# Altium schematic sheet Misc.SCHDOC(Misc)
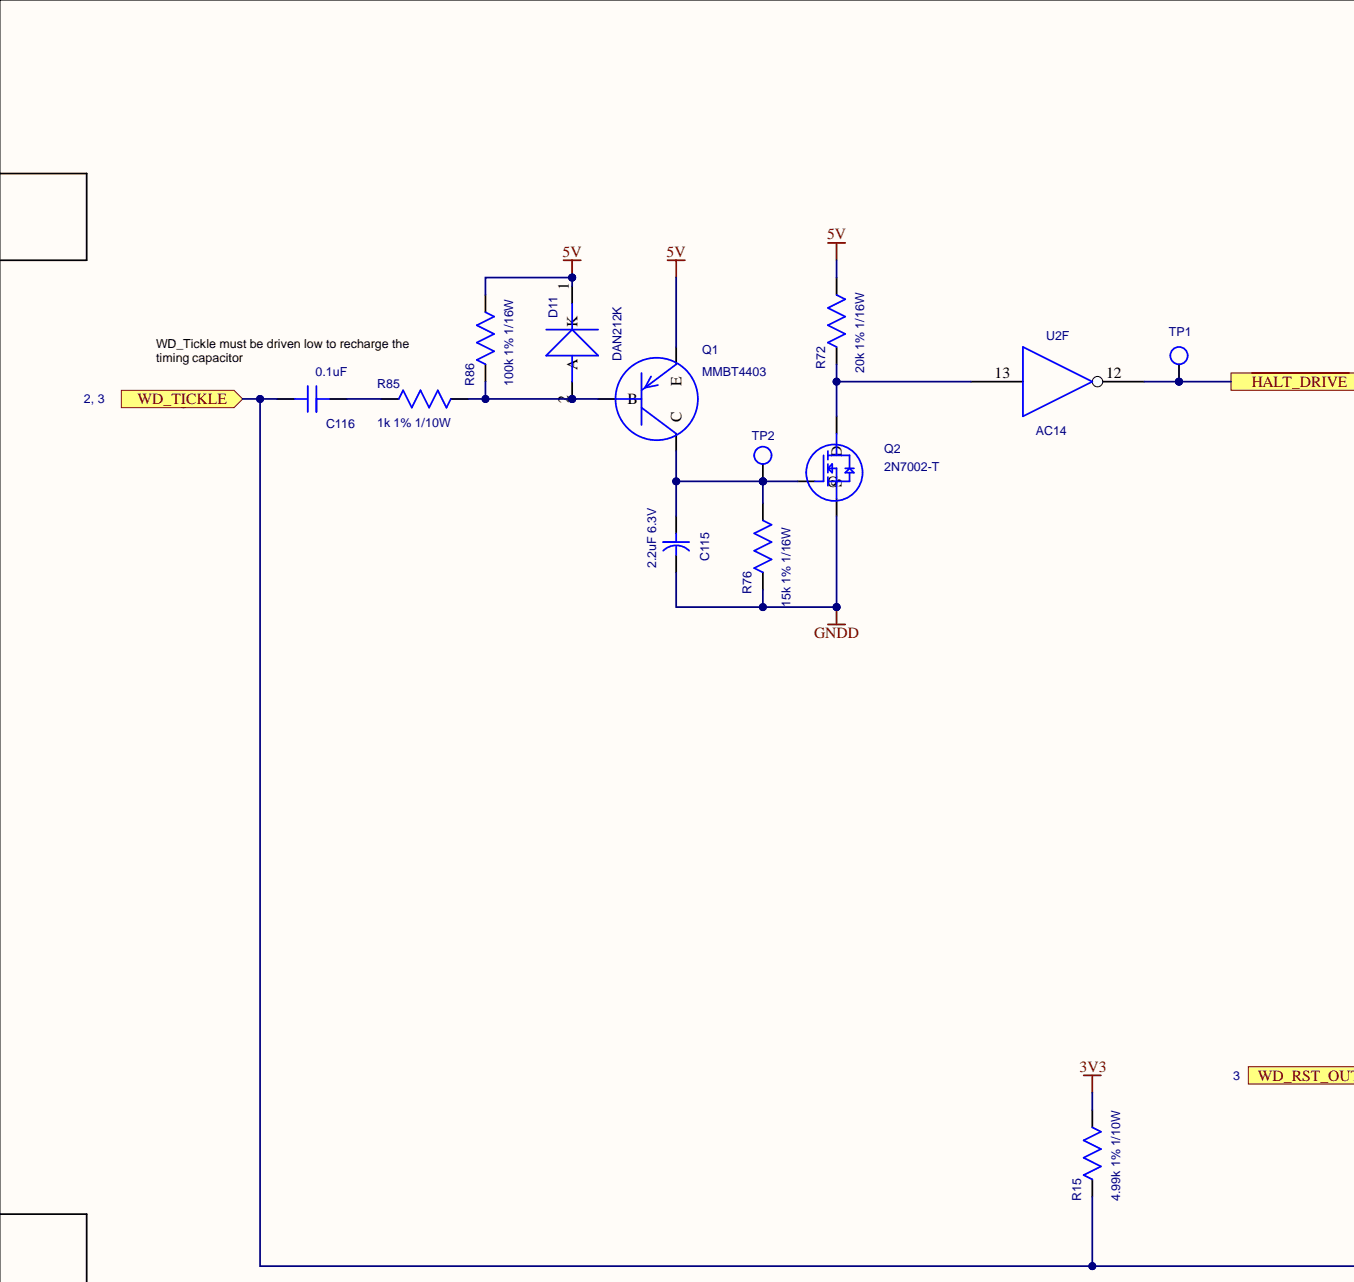
[diagram p0: Misc.SCHDOC, top left]
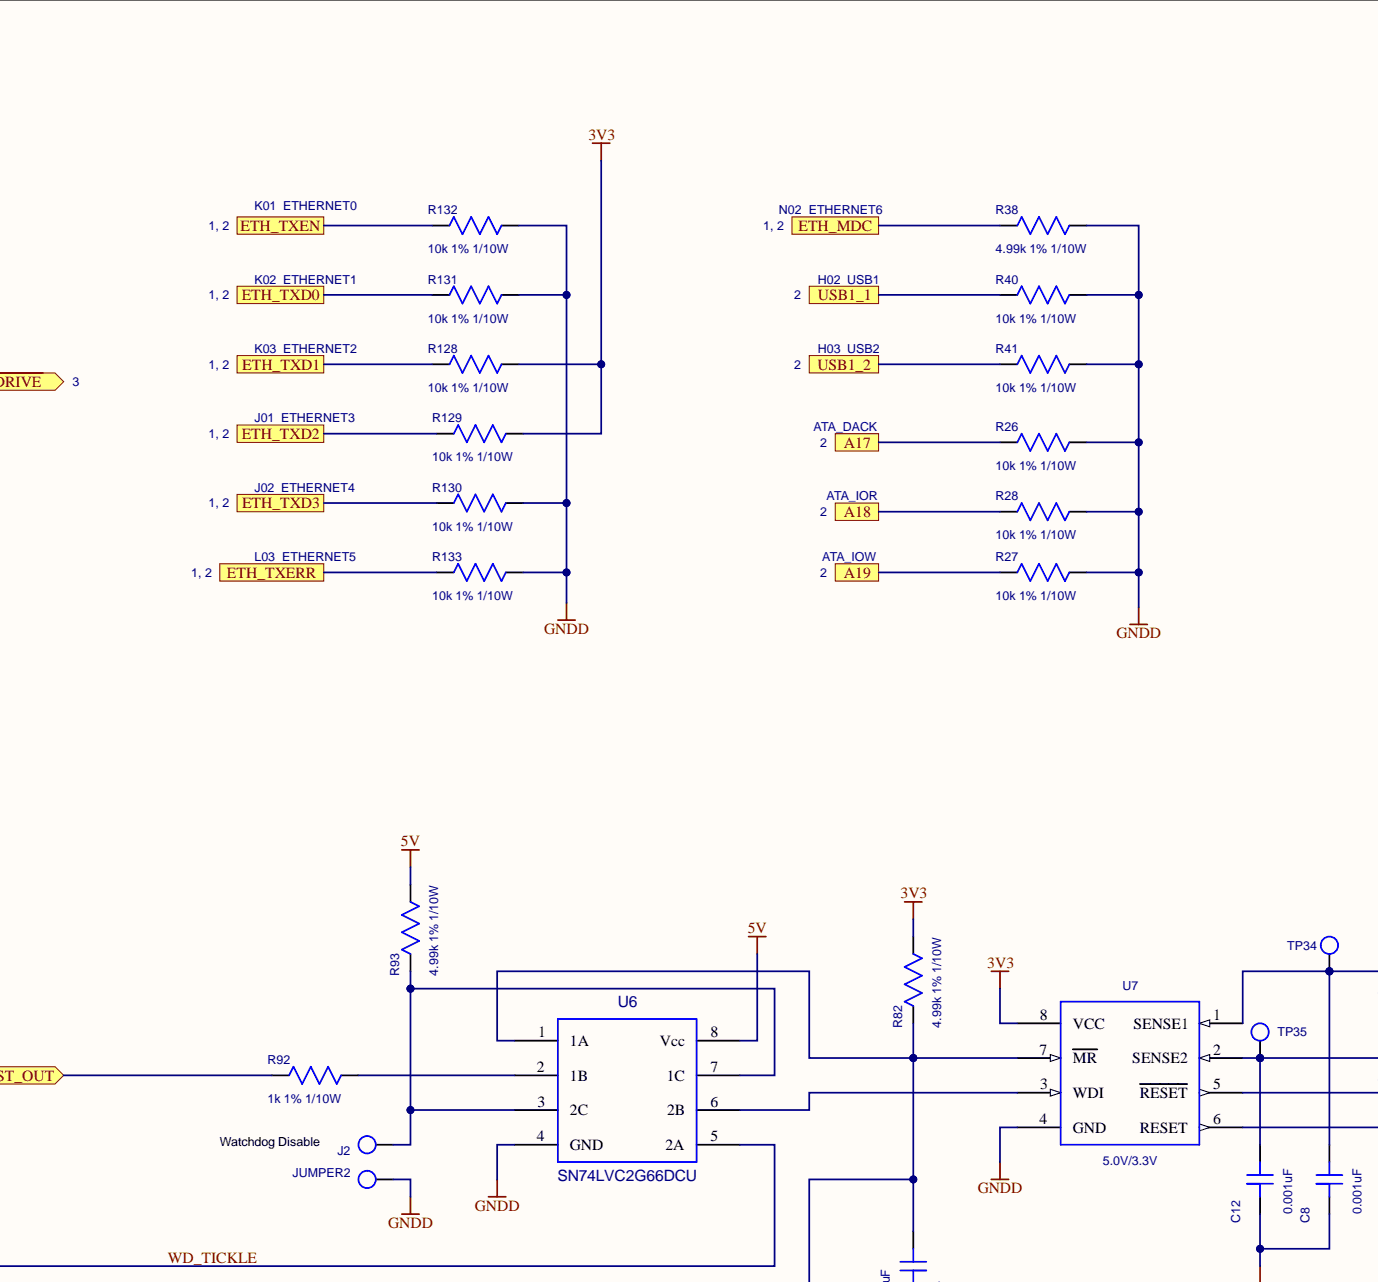
[diagram p1: Misc.SCHDOC, top centre]
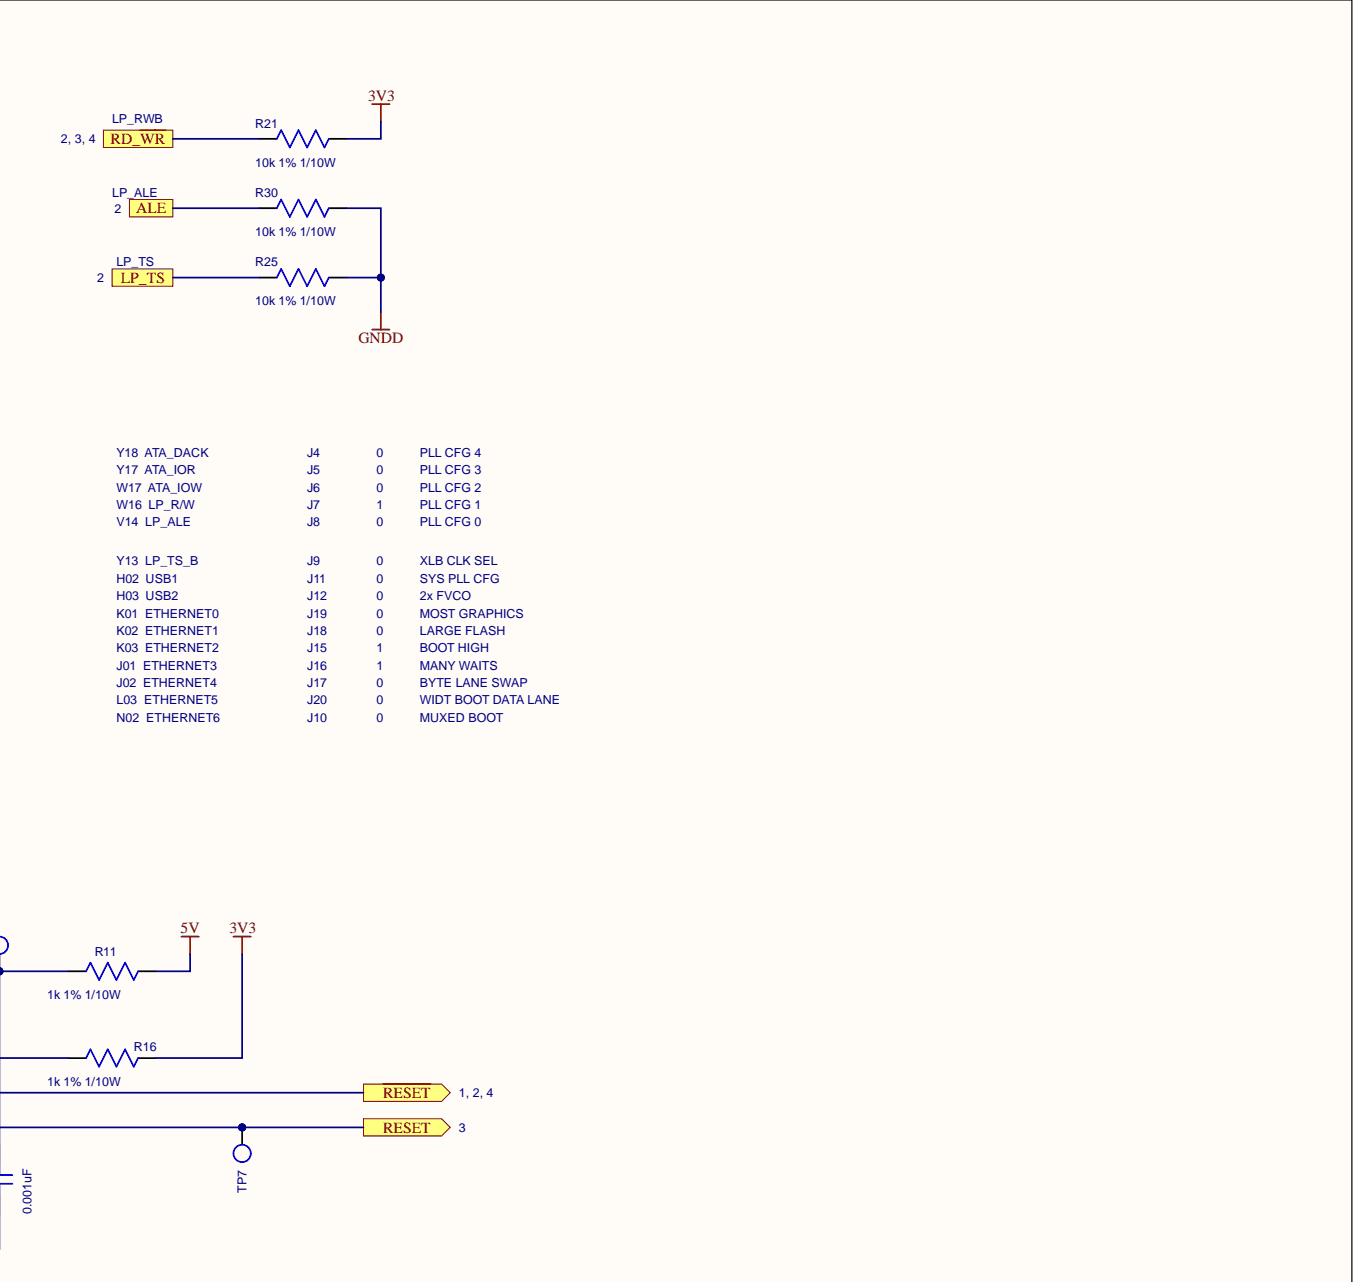
[diagram p2: Misc.SCHDOC, top right]
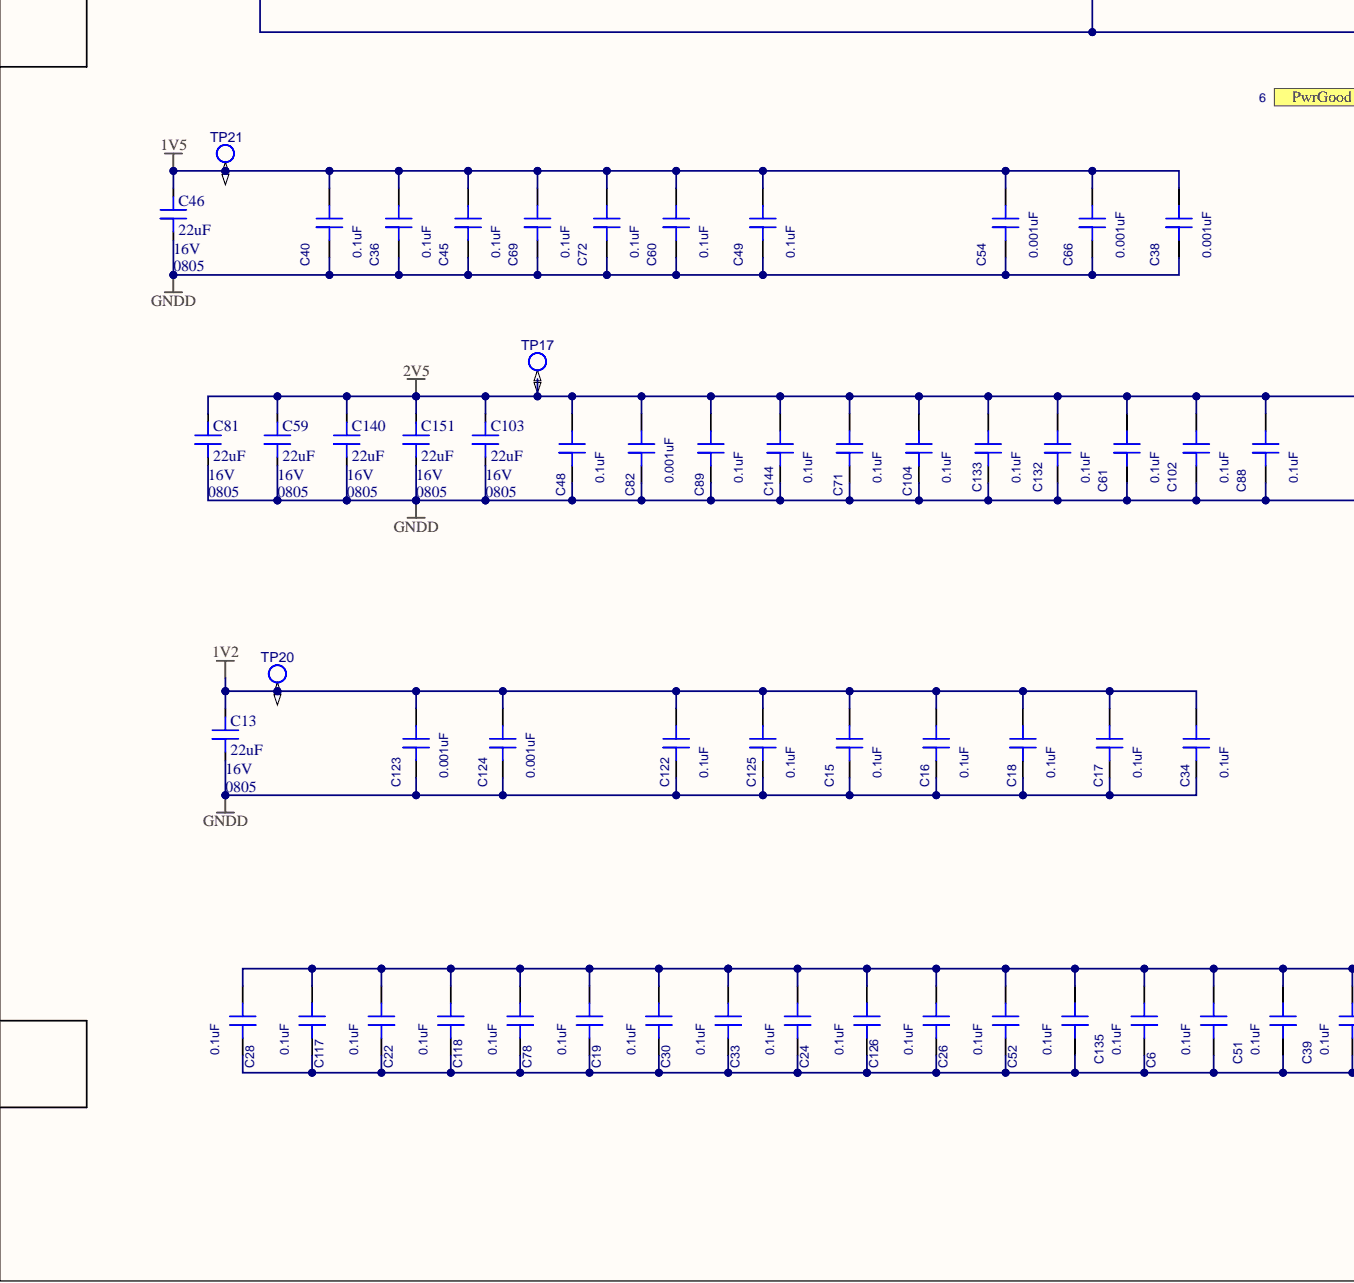
[diagram p3: Misc.SCHDOC, bottom left]
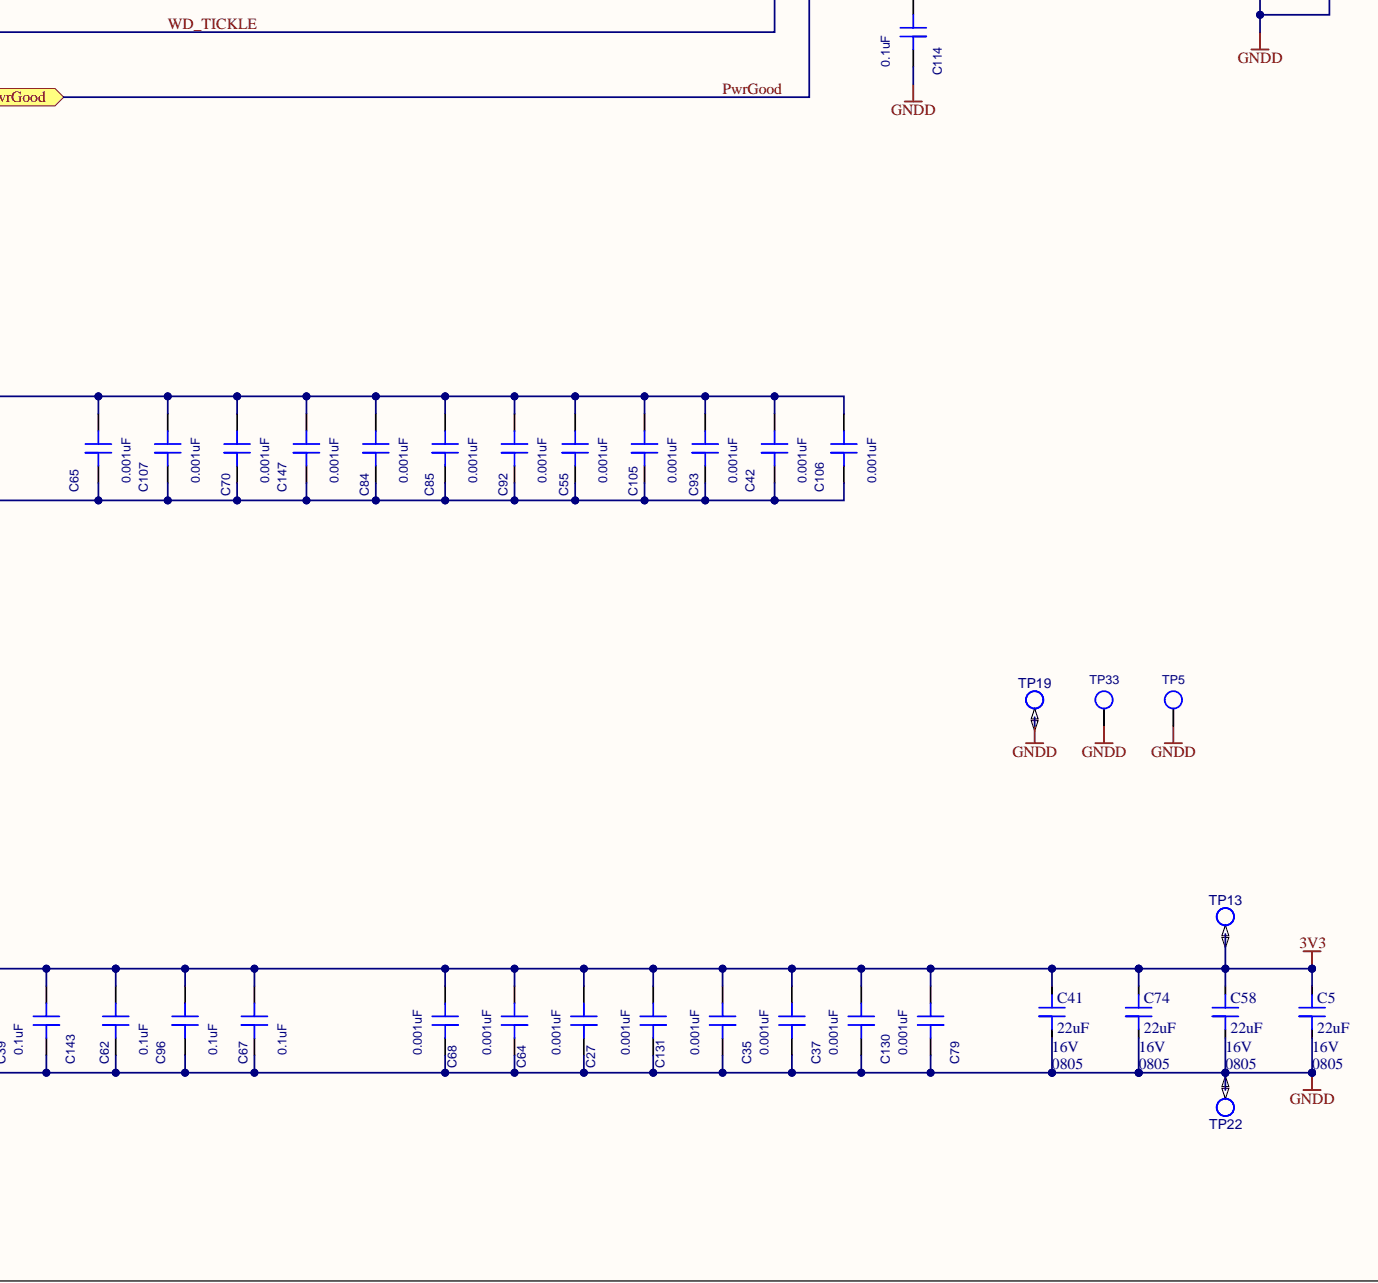
[diagram p4: Misc.SCHDOC, bottom centre]
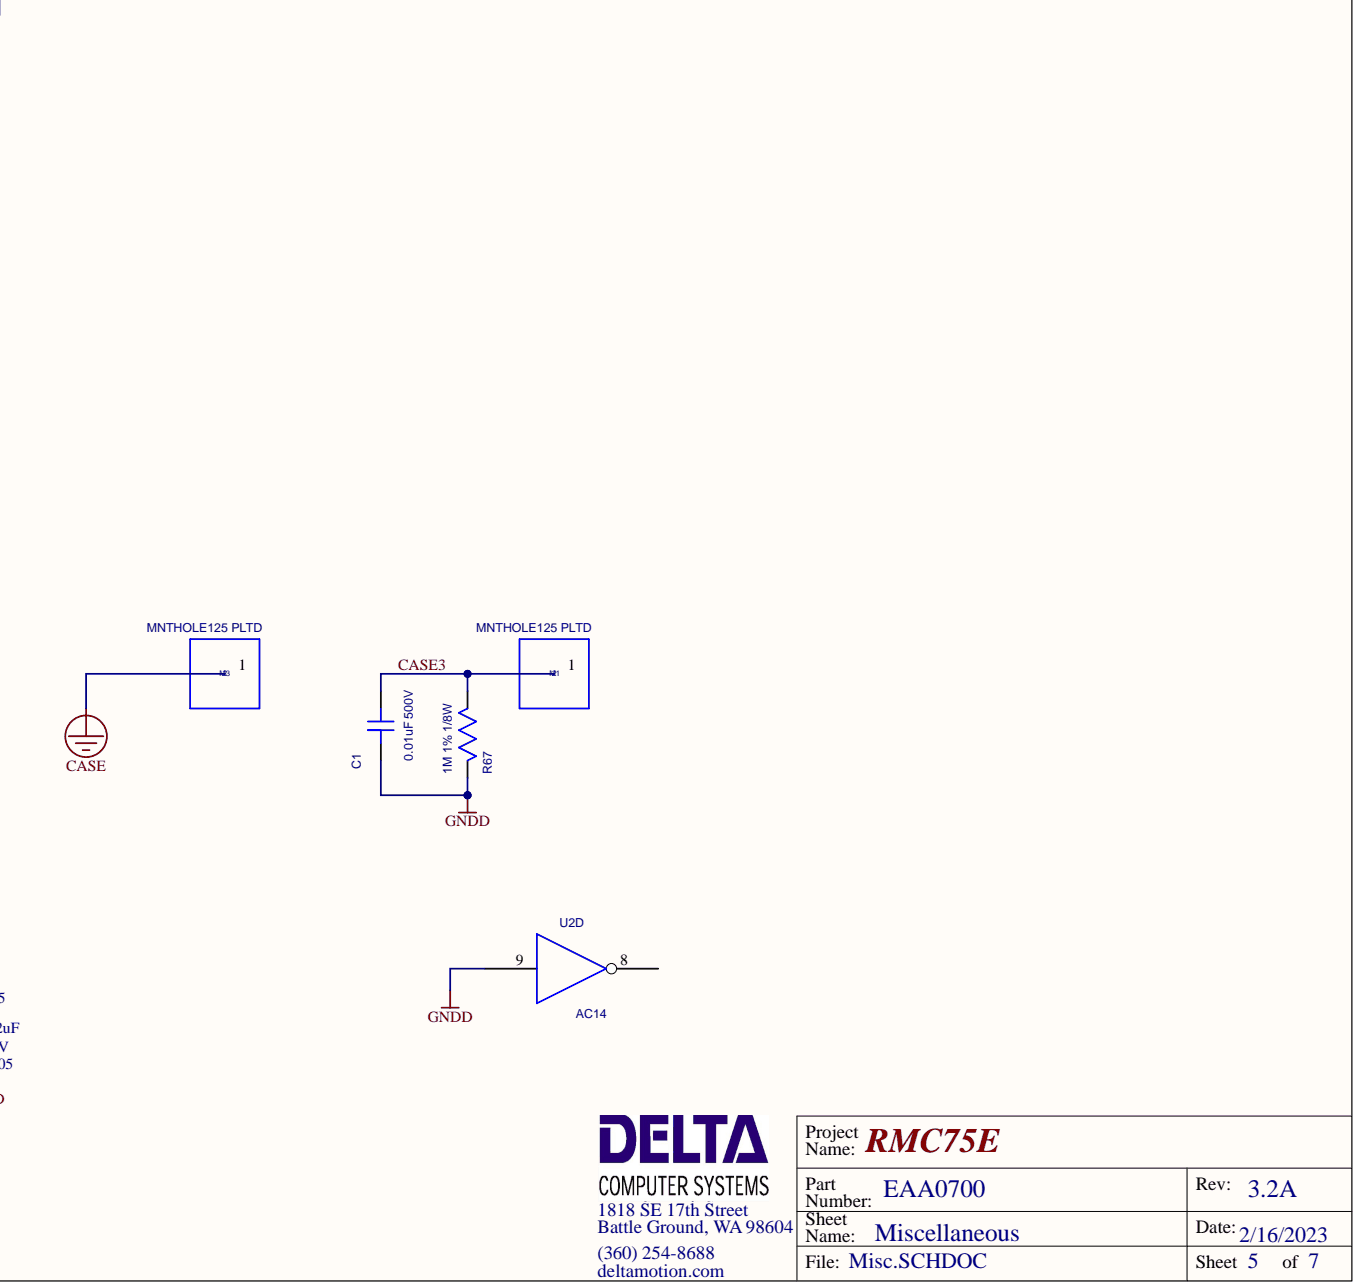
[diagram p5: Misc.SCHDOC, bottom right]

## Parts
C1  0.01uF 500V  [CC1210]
C5  22uF  CaseSize: 0805  RatedVoltage: 16V  [CAPC2012-N [0805]]
C6  0.1uF  [CC0603]
C8  0.001uF  [CC0603]
C12  0.001uF  [CC0603]
C13  22uF  CaseSize: 0805  RatedVoltage: 16V  [CAPC2012-N [0805]]
C15  0.1uF  [CC0603]
C16  0.1uF  [CC0603]
C17  0.1uF  [CC0603]
C18  0.1uF  [CC0603]
C19  0.1uF  [CC0603]
C22  0.1uF  [CC0603]
C24  0.1uF  [CC0603]
C26  0.1uF  [CC0603]
C27  0.001uF  [CC0603]
C28  0.1uF  [CC0603]
C30  0.1uF  [CC0603]
C33  0.1uF  [CC0603]
C34  0.1uF  [CC0603]
C35  0.001uF  [CC0603]
C36  0.1uF  [CC0603]
C37  0.001uF  [CC0603]
C38  0.001uF  [CC0603]
C39  0.1uF  [CC0603]
C40  0.1uF  [CC0603]
C41  22uF  CaseSize: 0805  RatedVoltage: 16V  [CAPC2012-N [0805]]
C42  0.001uF  [CC0603]
C45  0.1uF  [CC0603]
C46  22uF  CaseSize: 0805  RatedVoltage: 16V  [CAPC2012-N [0805]]
C48  0.1uF  [CC0603]
C49  0.1uF  [CC0603]
C51  0.1uF  [CC0603]
C52  0.1uF  [CC0603]
C54  0.001uF  [CC0603]
C55  0.001uF  [CC0603]
C58  22uF  CaseSize: 0805  RatedVoltage: 16V  [CAPC2012-N [0805]]
C59  22uF  CaseSize: 0805  RatedVoltage: 16V  [CAPC2012-N [0805]]
C60  0.1uF  [CC0603]
C61  0.1uF  [CC0603]
C62  0.1uF  [CC0603]
C64  0.001uF  [CC0603]
C65  0.001uF  [CC0603]
C66  0.001uF  [CC0603]
C67  0.1uF  [CC0603]
C68  0.001uF  [CC0603]
C69  0.1uF  [CC0603]
C70  0.001uF  [CC0603]
C71  0.1uF  [CC0603]
C72  0.1uF  [CC0603]
C74  22uF  CaseSize: 0805  RatedVoltage: 16V  [CAPC2012-N [0805]]
C78  0.1uF  [CC0603]
C79  0.001uF  [CC0603]
C81  22uF  CaseSize: 0805  RatedVoltage: 16V  [CAPC2012-N [0805]]
C82  0.001uF  [CC0603]
C84  0.001uF  [CC0603]
C85  0.001uF  [CC0603]
C88  0.1uF  [CC0603]
C89  0.1uF  [CC0603]
C92  0.001uF  [CC0603]
C93  0.001uF  [CC0603]
C96  0.1uF  [CC0603]
C102  0.1uF  [CC0603]
C103  22uF  CaseSize: 0805  RatedVoltage: 16V  [CAPC2012-N [0805]]
C104  0.1uF  [CC0603]
C105  0.001uF  [CC0603]
C106  0.001uF  [CC0603]
C107  0.001uF  [CC0603]
C114  0.1uF  [CC0603]
C115  2.2uF 6.3V  [CASEA]
C116  0.1uF  [CC0603]
C117  0.1uF  [CC0603]
C118  0.1uF  [CC0603]
C122  0.1uF  [CC0603]
C123  0.001uF  [CC0603]
C124  0.001uF  [CC0603]
C125  0.1uF  [CC0603]
C126  0.1uF  [CC0603]
C130  0.001uF  [CC0603]
C131  0.001uF  [CC0603]
C132  0.1uF  [CC0603]
C133  0.1uF  [CC0603]
C135  0.1uF  [CC0603]
C140  22uF  CaseSize: 0805  RatedVoltage: 16V  [CAPC2012-N [0805]]
C143  0.1uF  [CC0603]
C144  0.1uF  [CC0603]
C147  0.001uF  [CC0603]
C151  22uF  CaseSize: 0805  RatedVoltage: 16V  [CAPC2012-N [0805]]
D11  DAN212K  [SOT23_DAN212K(primary)]
J2  JUMPER2  [JMP2]  (no BOM)
M1  MNTHOLE125 PLTD  [MNTHLE-PLTD]  (no BOM)
M3  MNTHOLE125 PLTD  [MNTHLE-PLTD]  (no BOM)
Q1  MMBT4403  [SOT23_MMBT4403(Primary)]
Q2  2N7002-T  [SOT23]
R11  1k 1% 1/10W  [CC0603]
R15  4.99k 1% 1/10W  [CC0603]
R16  1k 1% 1/10W  [CC0603]
R21  10k 1% 1/10W  [CC0603]
R25  10k 1% 1/10W  [CC0603]
R26  10k 1% 1/10W  [CC0603]
R27  10k 1% 1/10W  [CC0603]
R28  10k 1% 1/10W  [CC0603]
R30  10k 1% 1/10W  [CC0603]
R38  4.99k 1% 1/10W  [CC0603]
R40  10k 1% 1/10W  [CC0603]
R41  10k 1% 1/10W  [CC0603]
R67  1M 1% 1/8W  [CC0805]
R72  20k 1% 1/16W  [CC0603]
R76  15k 1% 1/16W  [CC0603]
R82  4.99k 1% 1/10W  [CC0603]
R85  1k 1% 1/10W  [CC0603]
R86  100k 1% 1/16W  [CC0603]
R92  1k 1% 1/10W  [CC0603]
R93  4.99k 1% 1/10W  [CC0603]
R128  10k 1% 1/10W  [CC0603]
R129  10k 1% 1/10W  [CC0603]
R130  10k 1% 1/10W  [CC0603]
R131  10k 1% 1/10W  [CC0603]
R132  10k 1% 1/10W  [CC0603]
R133  10k 1% 1/10W  [CC0603]
TP1  TP_RND_SMT  [TP_SMT_RND]  (value not drawn)  (no BOM)
TP2  TP_RND_SMT  [TP_SMT_RND]  (value not drawn)  (no BOM)
TP5  TP_RND_SMT  [TP_SMT_RND]  (value not drawn)  (no BOM)
TP7  TP_RND_SMT  [TP_SMT_RND]  (value not drawn)  (no BOM)
TP13  TP1  [TESTP_XY60D40]  (no BOM)
TP17  TP1  [TESTP_XY60D40]  (no BOM)
TP19  TP1  [TESTP_XY60D40]  (no BOM)
TP20  TP1  [TESTP_XY60D40]  (value not drawn)  (no BOM)
TP21  TP1  [TESTP_XY60D40]  (value not drawn)  (no BOM)
TP22  TP1  [TESTP_XY60D40]  (value not drawn)  (no BOM)
TP33  TP_RND_SMT  [TP_SMT_RND]  (value not drawn)  (no BOM)
TP34  TP_RND_SMT  [TP_SMT_RND]  (value not drawn)  (no BOM)
TP35  TP_RND_SMT  [TP_SMT_RND]  (value not drawn)  (no BOM)
U2  value AC14  [SO14_ACT04-SO14()]  (hidden comment: ACT04-SO14)  (parts D,F)
U6  SN74LVC2G66DCU  [VSSOP8]
U7  5.0V/3.3V  [PSOP8]

## Ports
A17, A18, A19, ALE, ETH_MDC, ETH_TXD0, ETH_TXD1, ETH_TXD2, ETH_TXD3, ETH_TXEN, ETH_TXERR, LP_TS, PwrGood, RD_~{WR}, RESET, USB1_1, USB1_2, WD_RST_OUT, WD_TICKLE, ~{HALT_DRIVE}, ~{RESET}

## Nets
1V2: C13.1 C15.1 C16.1 C17.1 C18.1 C34.1 C122.1 C123.1 C124.1 C125.1 TP20.1
1V5: C36.1 C38.1 C40.1 C45.1 C46.1 C49.1 C54.1 C60.1 C66.1 C69.1 C72.1 TP21.1
2V5: C42.1 C48.1 C55.1 C59.1 C61.1 C65.1 C70.1 C71.1 C81.1 C82.1 C84.1 C85.1 C88.1 C89.1 C92.1 C93.1 C102.1 C103.1 C104.1 C105.1 C106.1 C107.1 C132.1 C133.1 C140.1 C144.1 C147.1 C151.1 TP17.1
3V3: C5.1 C6.1 C19.1 C22.1 C24.1 C26.1 C27.1 C28.1 C30.1 C33.1 C35.1 C37.1 C39.1 C41.1 C51.1 C52.1 C58.1 C62.1 C64.1 C67.1 C68.1 C74.1 C78.1 C79.1 C96.1 C117.1 C118.1 C126.1 C130.1 C131.1 C135.1 C143.1 R15.2 R16.1 R21.2 R82.2 R128.2 R129.2 TP13.1 U7.8(VCC)
5V: D11.1 Q1.E R11.1 R72.2 R86.2 R93.2 U6.8(Vcc)
CASE: M3.1
GNDD: C1.2 C5.2 C6.2 C8.2 C12.2 C13.2 C15.2 C16.2 C17.2 C18.2 C19.2 C22.2 C24.2 C26.2 C27.2 C28.2 C30.2 C33.2 C34.2 C35.2 C36.2 C37.2 C38.2 C39.2 C40.2 C41.2 C42.2 C45.2 C46.2 C48.2 C49.2 C51.2 C52.2 C54.2 C55.2 C58.2 C59.2 C60.2 C61.2 C62.2 C64.2 C65.2 C66.2 C67.2 C68.2 C69.2 C70.2 C71.2 C72.2 C74.2 C78.2 C79.2 C81.2 C82.2 C84.2 C85.2 C88.2 C89.2 C92.2 C93.2 C96.2 C102.2 C103.2 C104.2 C105.2 C106.2 C107.2 C114.2 C115.2 C117.2 C118.2 C122.2 C123.2 C124.2 C125.2 C126.2 C130.2 C131.2 C132.2 C133.2 C135.2 C140.2 C143.2 C144.2 C147.2 C151.2 J2.2 Q2.1 R25.2 R26.2 R27.2 R28.2 R30.2 R38.2 R40.2 R41.2 R67.1 R76.1 R130.2 R131.2 R132.2 R133.2 TP5.1 TP19.1 TP22.1 TP33.1 U2.9 U6.4(GND) U7.4(GND)
A17: R26.1 ; port A17
A18: R28.1 ; port A18
A19: R27.1 ; port A19
ALE: R30.1 ; port ALE
CASE3: C1.1 M1.1 R67.2
ETH_MDC: R38.1 ; port ETH_MDC
ETH_TXD0: R131.1 ; port ETH_TXD0
ETH_TXD1: R128.1 ; port ETH_TXD1
ETH_TXD2: R129.1 ; port ETH_TXD2
ETH_TXD3: R130.1 ; port ETH_TXD3
ETH_TXEN: R132.1 ; port ETH_TXEN
ETH_TXERR: R133.1 ; port ETH_TXERR
~{HALT_DRIVE}: TP1.1 U2.12 ; port ~{HALT_DRIVE}
LP_TS: R25.1 ; port LP_TS
PwrGood: C114.1 R82.1 U6.1(1A) U7.7(MR) ; port PwrGood
~{RESET}: U7.5(RESET) ; port ~{RESET}
RD_~{WR}: R21.1 ; port RD_~{WR}
RESET: TP7.1 U7.6(RESET) ; port RESET
USB1_1: R40.1 ; port USB1_1
USB1_2: R41.1 ; port USB1_2
WD_RST_OUT: R92.1 ; port WD_RST_OUT
WD_TICKLE: C116.1 R15.1 U6.5(2A) ; port WD_TICKLE
NetC8_1: C8.1 R11.2 TP34.1 U7.1(SENSE1)
NetC12_1: C12.1 R16.2 TP35.1 U7.2(SENSE2)
NetC115_1: C115.1 Q1.C Q2.2 R76.2 TP2.1
NetC116_2: C116.2 R85.1
NetD11_2: D11.2 Q1.B R85.2 R86.1
NetJ2_1: J2.1 R93.1 U6.3(2C) U6.7(1C)
NetQ2_3: Q2.3 R72.1 U2.13
NetR92_2: R92.2 U6.2(1B)
NetU6_6: U6.6(2B) U7.3(WDI)

Not connected: U2 8

## Library data of the parts
C1 = CAPNP_SMT1210: Cap Cer 0.01uF 500V 10% 1210 X7R; Manufacturer: Venkel; PartNumber: C1210X7R501-103KNE; Component Kind: Standard; Value: 0.01uF 500V
C5 C13 C41 C46 C58 C59 C74 C81 C103 C140 C151 = Capacitor - Non-polarized: Cap Ceramic X5R 22uF 20% 16V 0805; Manufacturer: Venkel; ManufacturerAlt1: Various; PartNumber: C0805X5R160-226MNE; Component Kind: Standard; ComponentType: Capacitor; DateUpdated: 03/11/2022; Library Name: Capacitor.IntLib; PartNumberAlt1: Various; Tolerance: 20%; Type: Ceramic X5R; Value: 22uF
C6 C15-C19 C22 C24 C26 C28 C30 C33 C34 C36 C39 C40 C45 C48 C49 C51 C52 C60-C62 C67 C69 C71 C72 C78 C88 C89 C96 C102 C104 C114 C116-C118 C122 C125 C126 C132 C133 C135 C143 C144 = CAPNP_SMT0603: Cap Cer 0.1uF 25V 10% 0603 X7R; Manufacturer: Venkel; PartNumber: C0603X7R250-104KNE; Component Kind: Standard; Value: 0.1uF
C8 C12 C27 C35 C37 C38 C42 C54 C55 C64-C66 C68 C70 C79 C82 C84 C85 C92 C93 C105-C107 C123 C124 C130 C131 C147 = CAPNP_SMT0603: Cap Cer 1000pF 50V 10% 0603 X7R; Manufacturer: Venkel; PartNumber: C0603X7R500-102KNE; Component Kind: Standard; Value: 0.001uF
C115 = CASEA: Cap Tant 2.2uF 10V 10% A; Manufacturer: Venkel; PartNumber: TA010TCM225KAR; Component Kind: Standard; Value: 2.2uF 6.3V
D11 = DAN212K: Diode GP 80V 100mA SMD3; Manufacturer: Rohm; PartNumber: DAN212KT146; Component Kind: Standard
Q1 = MMBT4403: Transistor PNP 600mA 40V SOT23; Manufacturer: On Semi; PartNumber: MMBT4403LT1G; Component Kind: Standard
Q2 = 2N7002-T: MOSFET N-Ch 115mA 60V SOT23; Manufacturer: Diodes Inc; PartNumber: 2N7002-7-F; Component Kind: Standard
R11 R16 R85 R92 = RES_GEN_SMT0603: Res 1k 1% 1/10W 100ppm 0603; Manufacturer: Venkel; PartNumber: CR0603-10W-1001FT; Component Kind: Standard; Value: 1k 1% 1/10W
R15 R38 R82 R93 = RES_GEN_SMT0603: Res 4.99k 1% 1/10W 100ppm 0603; Manufacturer: Venkel; PartNumber: CR0603-10W-4991FT; Component Kind: Standard; Value: 4.99k 1% 1/10W
R21 R25-R28 R30 R40 R41 R128-R133 = RES_GEN_SMT0603: Res 10k 1% 1/10W 100ppm 0603; Manufacturer: Venkel; PartNumber: CR0603-10W-1002FT; Component Kind: Standard; Value: 10k 1% 1/10W
R67 = RES_GEN_SMT0805: Res 1M 1% 1/8W 100ppm 0805; Manufacturer: Venkel; PartNumber: CR0805-8W-1004FT; Component Kind: Standard; Value: 1M 1% 1/8W
R72 = RES_GEN_SMT0603: Res 20k 1% 1/10W 100ppm 0603; Manufacturer: Venkel; PartNumber: CR0603-10W-2002FT; Component Kind: Standard; Value: 20k 1% 1/16W
R76 = RES_GEN_SMT0603: Res 15k 1% 1/10W 100ppm 0603; Manufacturer: Venkel; PartNumber: CR0603-10W-1502FT; Component Kind: Standard; Value: 15k 1% 1/16W
R86 = RES_GEN_SMT0603: Res 100k 1% 1/10W 100ppm 0603; Manufacturer: Venkel; PartNumber: CR0603-10W-1003FT; Component Kind: Standard; Value: 100k 1% 1/16W
TP13 TP17 TP19-TP21 = TP1: Value: 1.8V; Description: TP1
TP22 = TP1: Value: GND; Description: TP1
U2 = ACT04-SO14: Hex Inverter Schmitt Trigger 6-Ch 6-Inp 14SOIC; Manufacturer: Fairchild; PartNumber: 74ACT14SCX; Component Kind: Standard
U6 = SN74LVC2G66DCU: Switch Analog 2-Ch 2xSPST US8; Manufacturer: TI; PartNumber: SN74LVC2G66DCUR; Component Kind: Standard
U7 = TPS3305-18DGN: Volt Supervisor Dual Process 5V 8MSOP; Manufacturer: TI; PartNumber: TPS3305-33DGN; Component Kind: Standard; Value: 5.0V/3.3V
J2 = JUMPER2: Description: JUMPER2
M1 M3 = MNTHOLE125 PLTD: Description: MNTHOLE125 PLTD
TP1 TP2 TP5 TP7 TP33-TP35 = TP_RND_SMT: Description: TP_RND_SMT
